# Altium schematic sheet Bluetooth.SchDoc
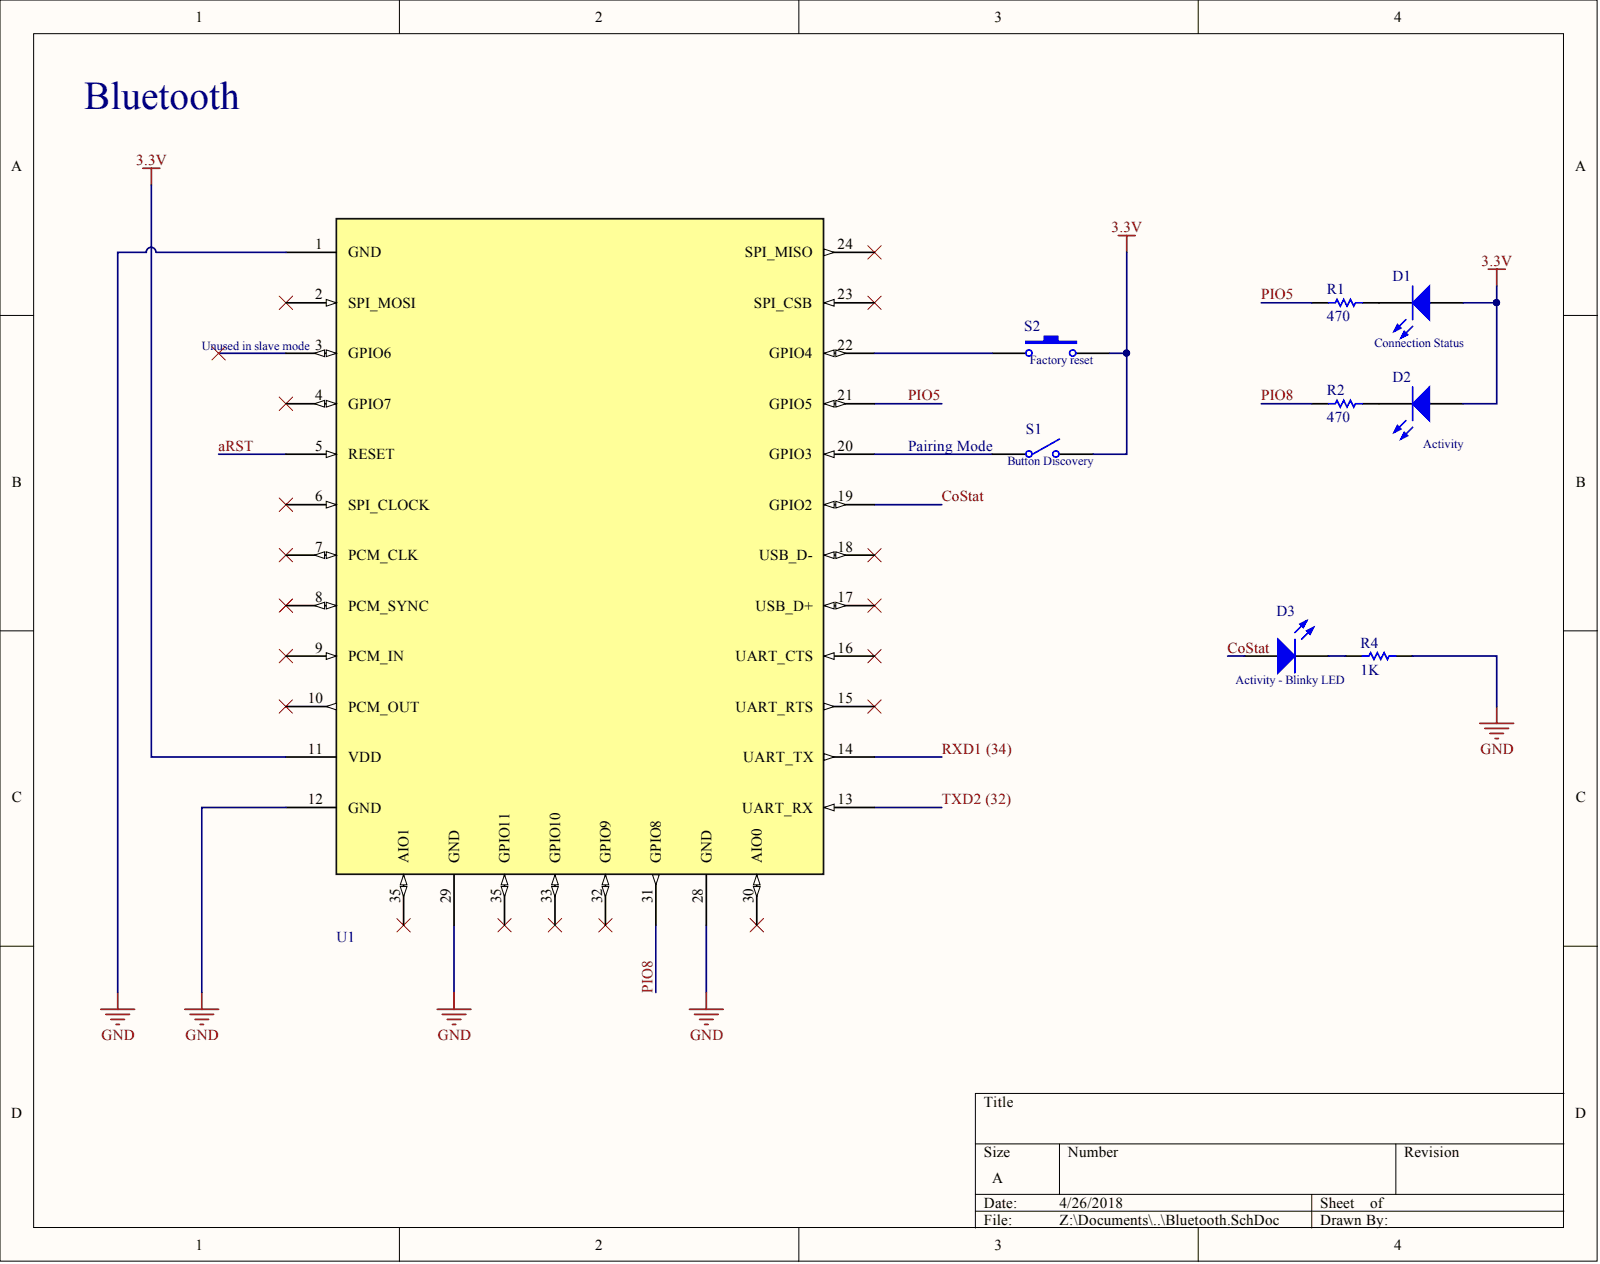
[diagram p0: Bluetooth.SchDoc]

## Parts
D1  Green LED  [LED-0]  (value not drawn)
D2  Red LED  [LED-0]  (value not drawn)
D3  Green LED  [LED-0]  (value not drawn)
R1  470  [AXIAL-0.3]
R2  470  [AXIAL-0.3]
R4  1K  [AXIAL-0.3]
S1  Single-Pole, Single-Throw Switch  [SPST-2]  (value not drawn)
S2  Switch  [SPST-2]  (value not drawn)
U1  RN42  (value not drawn)

## Nets
3.3V: D1.1 D2.1 S1.2 S2.2 U1.11(VDD)
GND: R4.1 U1.1(GND) U1.12(GND) U1.28(GND) U1.29(GND)
ARST: U1.5(RESET)
COSTAT: D3.1 U1.19(GPIO2)
PIO5: R1.1 U1.21(GPIO5)
PIO8: R2.1 U1.31(GPIO8)
RXD1 (34): U1.14(UART_TX)
TXD2 (32): U1.13(UART_RX)
NetD1_2: D1.2 R1.2
NetD2_2: D2.2 R2.2
NetD3_2: D3.2 R4.2
NetS1_1: S1.1 U1.20(GPIO3)
NetS2_1: S2.1 U1.22(GPIO4)

Not connected: U1 2(SPI_MOSI) 3(GPIO6) 4(GPIO7) 6(SPI_CLOCK) 7(PCM_CLK) 8(PCM_SYNC) 9(PCM_IN) 10(PCM_OUT) 15(UART_RTS) 16(UART_CTS) 17(USB_D+) 18(USB_D-) 23(SPI_CSB) 24(SPI_MISO) 30(AIO0) 32(GPIO9) 33(GPIO10) 35(AIO1)

## Library data of the parts
D1 = LED0: Connection Status; Component Kind: Standard; LatestRevisionDate: 08-Jul-2005; LatestRevisionNote: Mech Layer 1 removed.; Library Name: Miscellaneous Devices.IntLib; PackageDescription: LED; 2 Leads; PackageReference: LED-0; Published: 8-Jun-2000; Simulation: LED0; Publisher: Altium Limited
D2 = LED0: Activity; Component Kind: Standard; LatestRevisionDate: 08-Jul-2005; LatestRevisionNote: Mech Layer 1 removed.; Library Name: Miscellaneous Devices.IntLib; PackageDescription: LED; 2 Leads; PackageReference: LED-0; Published: 8-Jun-2000; Simulation: LED0; Publisher: Altium Limited
D3 = LED0: Blinky LED; Component Kind: Standard; LatestRevisionDate: 08-Jul-2005; LatestRevisionNote: Mech Layer 1 removed.; Library Name: Miscellaneous Devices.IntLib; PackageDescription: LED; 2 Leads; PackageReference: LED-0; Published: 8-Jun-2000; Simulation: LED0; Publisher: Altium Limited
R1 R2 R4 = Res1: Resistor; Component Kind: Standard; LatestRevisionDate: 17-Jul-2002; LatestRevisionNote: Re-released for DXP Platform.; Library Name: Miscellaneous Devices.IntLib; PackageDescription: Axial Device, Thru-Hole; 2 Leads; 0.3 in Pin Spacing; PackageReference: AXIAL-0.3; Published: 8-Jun-2000; Signal Integrity: Res; Simulation: RESISTOR; Publisher: Altium Limited
S1 = SW-SPST: Button Discovery; Component Kind: Standard; LatestRevisionDate: 17-Jul-2002; LatestRevisionNote: Re-released for DXP Platform.; Library Name: Miscellaneous Devices.IntLib; PackageDescription: Switch; 2 Leads; PackageReference: SPST-2; Published: 24-Mar-1999; Publisher: Altium Limited
S2 = SW-PB: Factory reset; Component Kind: Standard; LatestRevisionDate: 17-Jul-2002; LatestRevisionNote: Re-released for DXP Platform.; Library Name: Miscellaneous Devices.IntLib; PackageDescription: Switch; 2 Leads; PackageReference: SPST-2; Published: 24-Mar-1999; Publisher: Altium Limited
U1 = RN42: Bluetooth Module; Fabricant: Microchip; Fournisseur: Farnell; RefFabricant: RN42-I/RM; RefFournisseur: 2143310; Component Kind: Standard; Library Name: Schlib.SchLib
